# Altium schematic sheet 02_ RF & Power.SchDoc
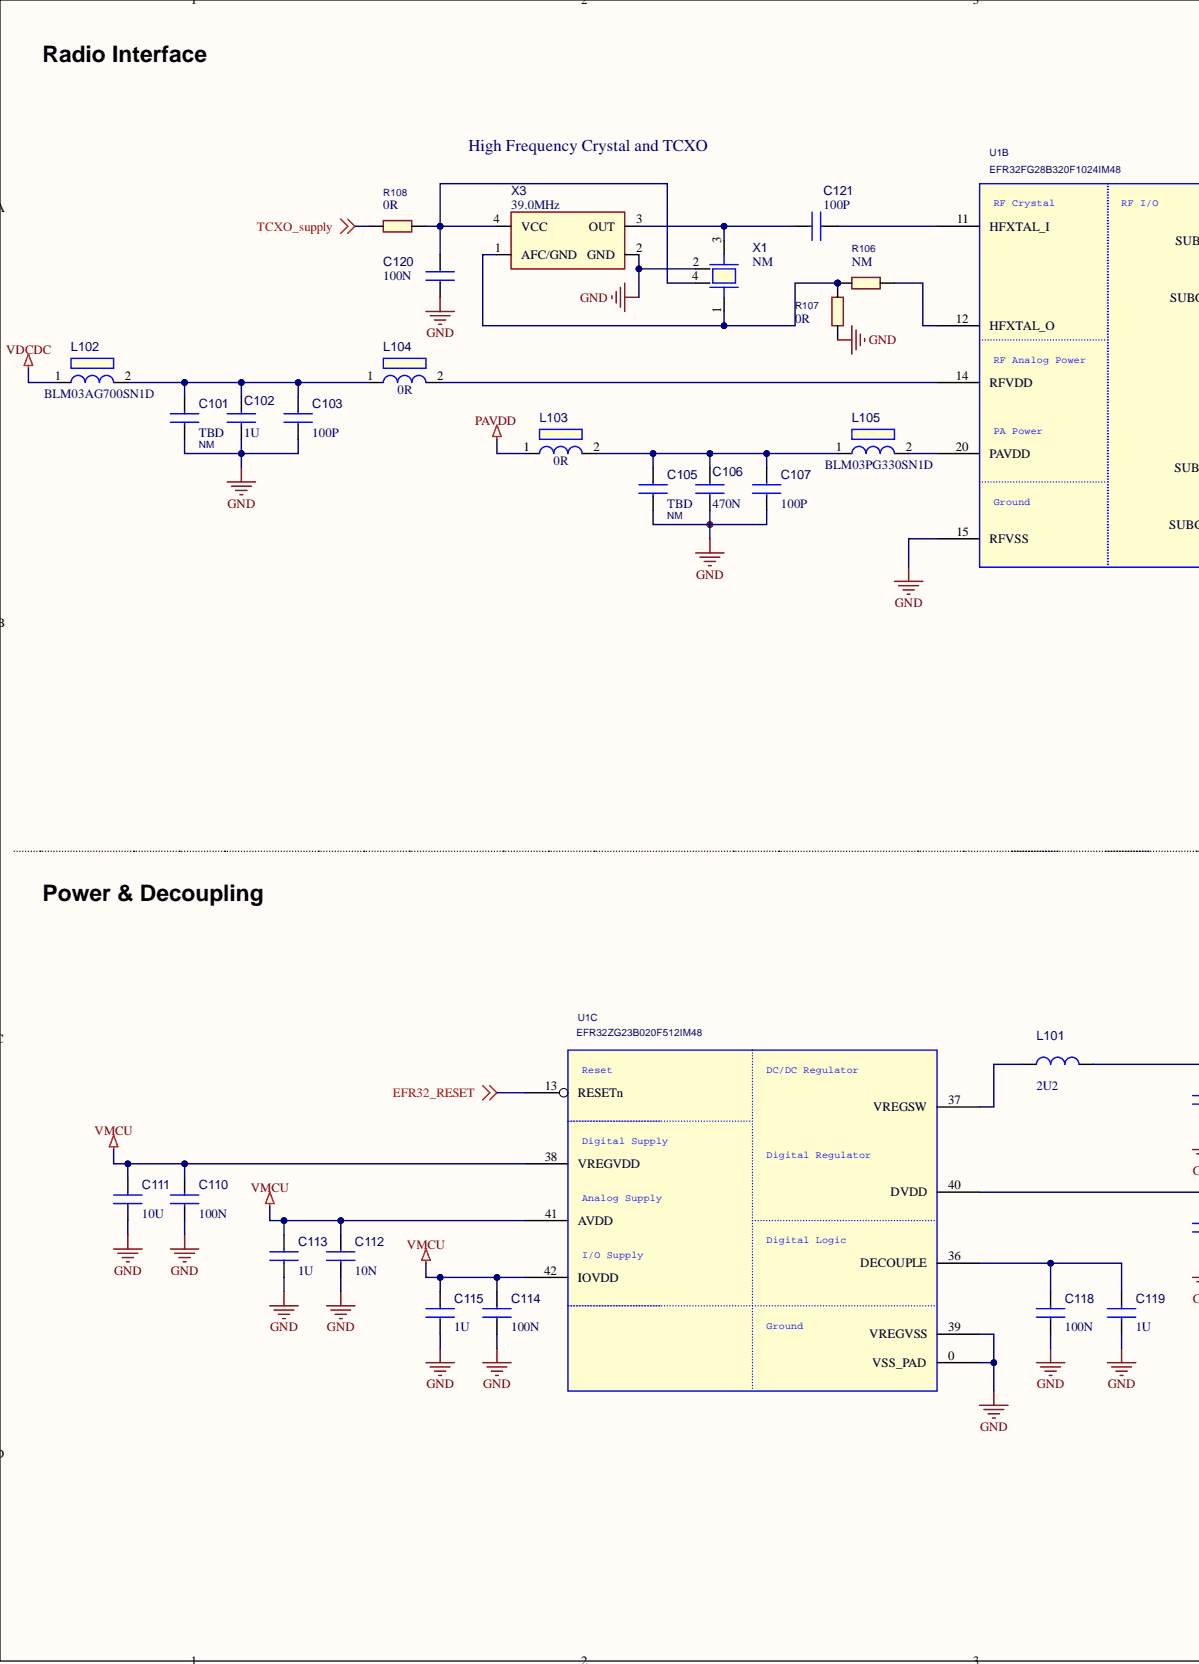
[diagram p0: 02_ RF & Power.SchDoc, left]
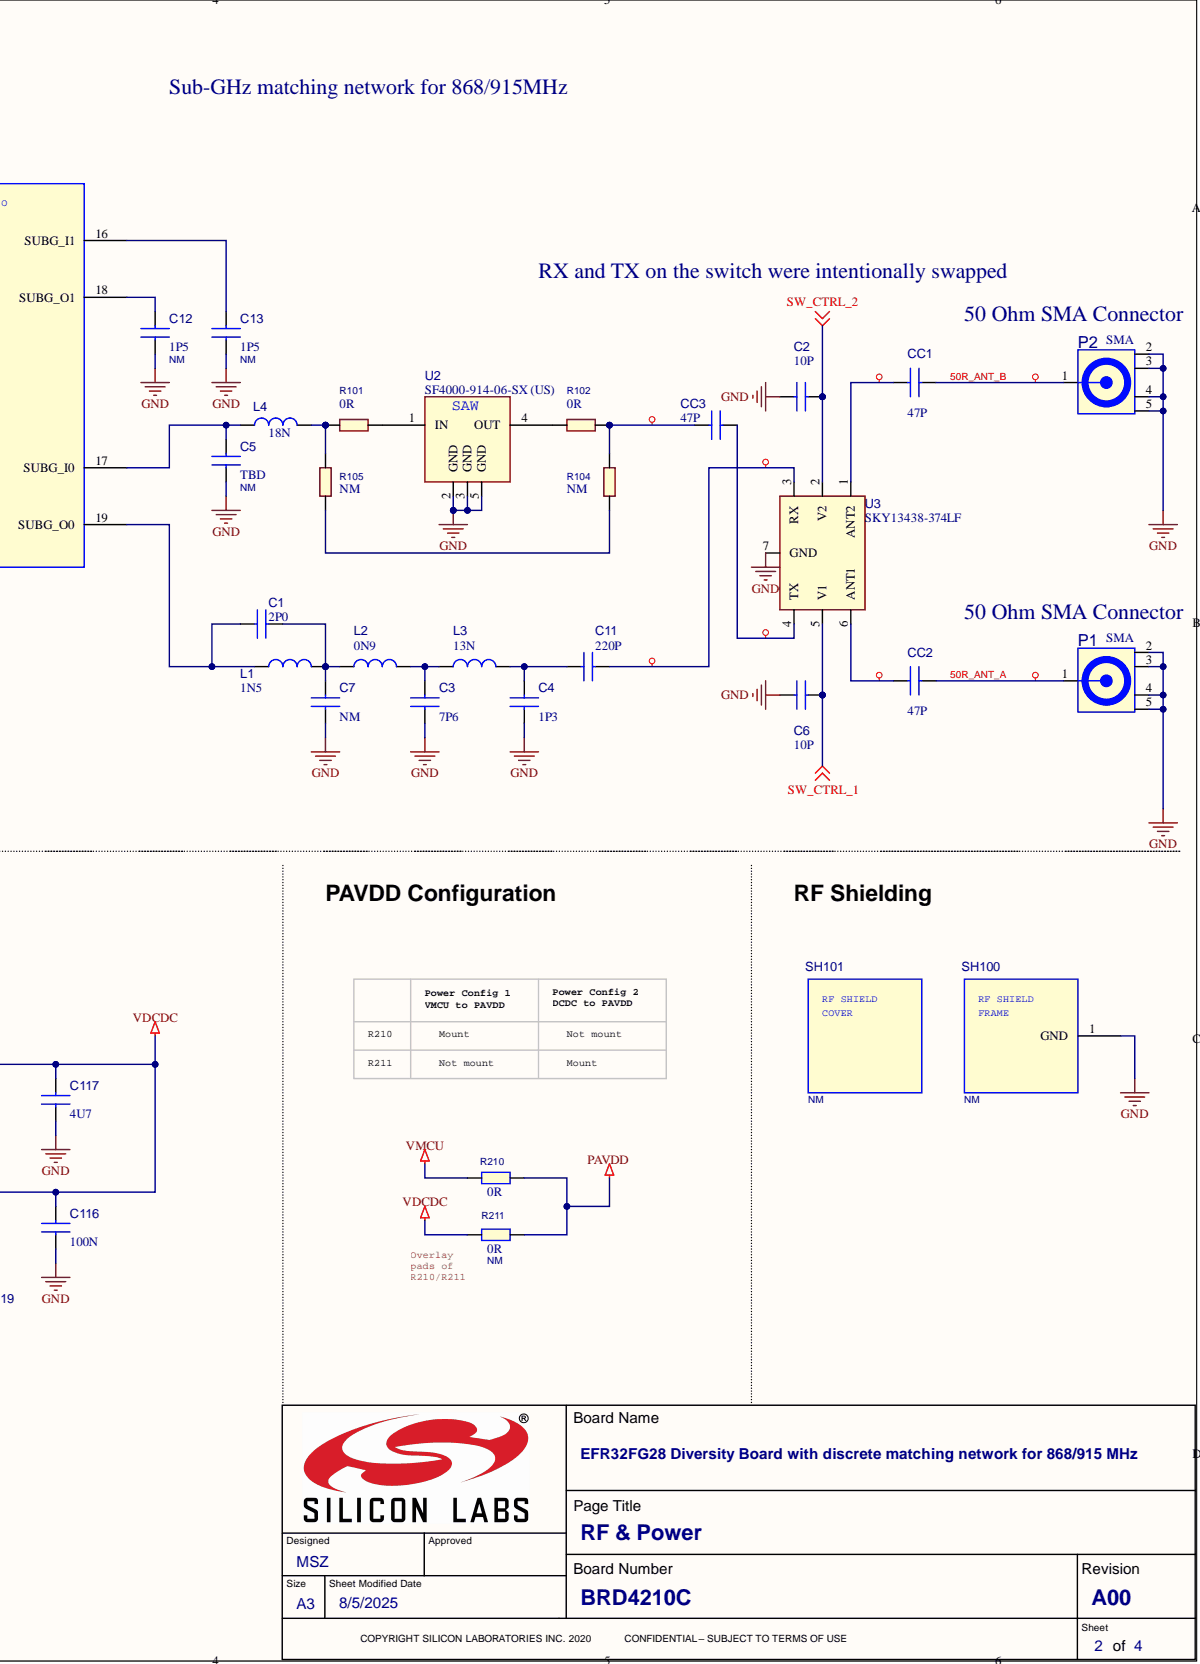
[diagram p1: 02_ RF & Power.SchDoc, right]

## Parts
C1  2P0  Part Reference: C1  [C0201_L]
C2  10P  [C0201_L]
C3  7P6  Part Reference: C3  [C0201_L]
C4  1P3  Part Reference: C4  [C0201_L]
C5  TBD  Not Mounted: NM  Part Reference: C5  [C0201_L]
C6  10P  [C0201_L]
C7  NM  [C0201_L]
C11  220P  Part Reference: C11  [C0201_L]
C12  1P5  Not Mounted: NM  Part Reference: C12  [C0201_L]
C13  1P5  Not Mounted: NM  Part Reference: C13  [C0201_L]
C101  TBD  Not Mounted: NM  Part Reference: C101  [C0201_L]
C102  1U  Part Reference: C102  [C0402_L]
C103  100P  Part Reference: C103  [C0201_L]
C105  TBD  Not Mounted: NM  Part Reference: C105  [C0201_L]
C106  470N  Part Reference: C106  [C0402_L]
C107  100P  Part Reference: C107  [C0201_L]
C110  100N  Part Reference: C110  [C0201_L]
C111  10U  Part Reference: C111  [C0603_L]
C112  10N  Part Reference: C112  [C0201_L]
C113  1U  Part Reference: C113  [C0402_L]
C114  100N  Part Reference: C114  [C0201_L]
C115  1U  Part Reference: C115  [C0402_L]
C116  100N  Part Reference: C116  [C0201_L]
C117  4U7  Part Reference: C117  [C0603_L]
C118  100N  Part Reference: C118  [C0201_L]
C119  1U  Part Reference: C119  [C0402_L]
C120  100N  [C0201_L]
C121  100P  [C0201_L]
CC1  47P  [C0201_L]
CC2  47P  [C0201_L]
CC3  47P  [C0201_L]
L1  1N5  Part Reference: L1  [COIL_0201_L]
L2  0N9  Part Reference: L2  [COIL_0201_L]
L3  13N  Part Reference: L3  [COIL_0201_L]
L4  18N  Part Reference: L4  [COIL_0201_L]
L101  2U2  Part Reference: L101  [COIL_1008_L]
L102  BLM03AG700SN1D  Part Reference: L102  [COIL_MUR_BLM03_0201]
L103  0R  Part Reference: L103  [COIL_MUR_BLM03_0201]
L104  0R  Part Reference: L104  [COIL_MUR_BLM03_0201]
L105  BLM03PG330SN1D  Part Reference: L105  [COIL_MUR_BLM03_0201]
P1  SMA  Part Reference: P1  [TE_SMA_1814832_TH_VER]
P2  SMA  [TE_SMA_1814832_TH_VER]
R101  0R  [R0201_L]
R102  0R  [R0201_L]
R104  NM  [R0201_L]
R105  NM  [R0201_L]
R106  NM  [R0201_L]
R107  0R  [R0201_L]
R108  0R  [R0201_L]
R210  0R  Part Reference: R210  [R0402_L]
R211  0R  Not Mounted: NM  Part Reference: R211  [R0402_L]
SH100  Not Mounted: NM  Part Reference: SH100  [Shield_21x21mm]  (hidden comment: RF_SHIELD_FRAME_36103205S)
SH101  Not Mounted: NM  Part Reference: SH101  (hidden comment: RF_SHIELD_COVER_36003200S)
U1  EFR32FG28B320F1024IM48  [QFN48_M040_6.0X6.0_EP_SL]  (parts B,C)
U2  SF4000-914-06-SX (US)  [SF1256]
U3  SKY13438-374LF  [SKY13438-374LF]
X1  NM  Part Reference: X1  [X_SSS_DSX211SH_2.05X1.65-4]
X3  39.0MHz  [TCXO_7Z-38.400MBG-T_SMD2016_TXC]

## Nets
EFR32_RESET: U1.13(RESETn)
GND: C2.1 C3.2 C4.2 C5.2 C6.1 C7.2 C12.2 C13.2 C101.2 C102.2 C103.2 C105.2 C106.2 C107.2 C110.2 C111.2 C112.2 C113.2 C114.2 C115.2 C116.2 C117.2 C118.2 C119.2 C120.2 P1.2 P1.3 P1.4 P1.5 P2.2 P2.3 P2.4 P2.5 R107.2 SH100.1 U1.0(VSS_PAD) U1.15(RFVSS) U1.39(VREGVSS) U2.2(GND) U2.3(GND) U2.5(GND) U3.7(GND) X1.2 X3.2(GND)
PAVDD: L103.1 R210.1 R211.2
SW_CTRL_1: C6.2 U3.5(V1)
SW_CTRL_2: C2.2 U3.2(V2)
TCXO_supply: R108.1
VDCDC: C116.1 C117.1 L101.2 L102.1 R211.1 U1.40(DVDD)
VMCU: C110.1 C111.1 C112.1 C113.1 C114.1 C115.1 R210.2 U1.38(VREGVDD) U1.41(AVDD) U1.42(IOVDD)
50R_ANT_A: CC2.2 P1.1
50R_ANT_B: CC1.2 P2.1
NetC1_1: C1.1 L1.1 U1.19(SUBG_O0)
NetC1_2: C1.2 C7.1 L1.2 L2.1
NetC3_1: C3.1 L2.2 L3.1
NetC4_1: C4.1 C11.1 L3.2
NetC5_1: C5.1 L4.1 U1.17(SUBG_I0)
NetC11_2: C11.2 U3.3(RX)
NetC12_1: C12.1 U1.18(SUBG_O1)
NetC13_1: C13.1 U1.16(SUBG_I1)
NetC101_1: C101.1 C102.1 C103.1 L102.2 L104.1
NetC105_1: C105.1 C106.1 C107.1 L103.2 L105.1
NetC118_1: C118.1 C119.1 U1.36(DECOUPLE)
NetC120_1: C120.1 R108.2 X1.4 X3.4(VCC)
NetC121_1: C121.1 X1.3 X3.3(OUT)
NetC121_2: C121.2 U1.11(HFXTAL_I)
NetCC1_1: CC1.1 U3.1(ANT2)
NetCC2_1: CC2.1 U3.6(ANT1)
NetCC3_1: CC3.1 R102.2 R104.1
NetCC3_2: CC3.2 U3.4(TX)
NetL4_2: L4.2 R101.1 R105.1
NetL101_1: L101.1 U1.37(VREGSW)
NetL104_2: L104.2 U1.14(RFVDD)
NetL105_2: L105.2 U1.20(PAVDD)
NetR101_2: R101.2 U2.1(IN)
NetR102_1: R102.1 U2.4(OUT)
NetR104_2: R104.2 R105.2
NetR106_1: R106.1 R107.1 X1.1 X3.1(AFC/GND)
NetR106_2: R106.2 U1.12(HFXTAL_O)

## Library data of the parts
C1 = C0201: Mfg: Murata; Mfg #: GRM0335C1H1R9BA01D; Tolerance: ±0.1pF; Value: 1P9; Component Kind: Standard; PCB Footprint: C0201_L; Technology: C0G
C2 C6 CC1-CC3 = C0201: Part Reference: CC11; Mfg: Murata; Mfg #: GJM0335C1E180GB01D; Tolerance: ±2%; Component Kind: Standard; PCB Footprint: C0201_L; Technology: C0G
  C2 C6: Value: 10P
  CC1-CC3: Value: 47P
C3 = C0201: Mfg: Murata; Mfg #: GRM0335C1H7R2BA01D; Tolerance: ±0.1pF; Value: 7P2; Component Kind: Standard; PCB Footprint: C0201_L; Technology: C0G
C4 = C0201: Mfg: Murata; Mfg #: GRM0335C1H1R3BA01D; Tolerance: ±0.1pF; Component Kind: Standard; PCB Footprint: C0201_L; Technology: C0G; Value: 1P3
C5 = C0201: Component Kind: Standard; PCB Footprint: C0201_L; Value: TBD
C7 = C0201: Part Reference: C1; Mfg: Murata; Mfg #: GRM0335C1H1R9BA01D; Tolerance: ±0.1pF; Component Kind: Standard; PCB Footprint: C0201_L; Technology: C0G; Value: NM
C11 = C0201: Mfg: Murata; Mfg #: GRM0335C1H221GA01D; Tolerance: ±2%; Component Kind: Standard; PCB Footprint: C0201_L; Technology: C0G; Value: 220P
C12 C13 = C0201: Mfg: Murata; Mfg #: GRM0335C1E1R5WA01D; Tolerance: ±0.05pF; Component Kind: Standard; PCB Footprint: C0201_L; Technology: C0G; Value: 1P5
C101 C105 = C0201: Rating: 50V; Tolerance: +/-0.05P; Component Kind: Standard; PCB Footprint: C0201_L; Technology: C0G; Value: TBD
C102 C113 C115 C119 = C0402: Rating: 6.3V; Tolerance: 10%; Component Kind: Standard; PCB Footprint: C0402_L; Technology: X7R; Value: 1U
C103 C107 = C0201: Rating: 25V; Tolerance: 5%; Component Kind: Standard; PCB Footprint: C0201_L; Technology: C0G; Value: 100P
C106 = C0402: Rating: 10V; Tolerance: 10%; Component Kind: Standard; PCB Footprint: C0402_L; Technology: X7R; Value: 470N
C110 C114 C116 C118 = C0201: Rating: 16V; Tolerance: 20%; Component Kind: Standard; PCB Footprint: C0201_L; Technology: X7S; Value: 100N
C111 = C0603: Rating: 25V; Tolerance: 10%; Component Kind: Standard; PCB Footprint: C0603_L; Technology: X5R; Value: 10U
C112 = C0201: Rating: 16V; Tolerance: 10%; Component Kind: Standard; PCB Footprint: C0201_L; Technology: X7S; Value: 10N
C117 = C0603: Rating: 6.3V; Tolerance: 10%; Component Kind: Standard; PCB Footprint: C0603_L; Technology: X7R; Value: 4U7
C120 C121 = C0201: Part Reference: C13; Mfg: Murata; Mfg #: GRM0335C1E1R5WA01D; Tolerance: ±0.05pF; Component Kind: Standard; PCB Footprint: C0201_L; Technology: C0G
  C120: Value: 100N
  C121: Value: 100P
L1 = L0201: Mfg: Murata; Mfg #: LQP03HQ1N5W02D; Tolerance: ±0.05nH; Component Kind: Standard; PCB Footprint: COIL_0201_L; Value: 1N5
L2 = L0201: Mfg: Murata; Mfg #: LQP03HQ1N3W02D; Tolerance: ±0.05nH; Value: 1N3; Component Kind: Standard; PCB Footprint: COIL_0201_L
L3 = L0201: Mfg: Murata; Mfg #: LQP03HQ13NH02D; Tolerance: ±3%; Component Kind: Standard; PCB Footprint: COIL_0201_L; Value: 13N
L4 = L0201: Mfg: Murata; Mfg #: LQP03HQ18NH02D; Tolerance: ±3%; Component Kind: Standard; PCB Footprint: COIL_0201_L; Value: 18N
L101 = L1008: Mfg: Murata; Mfg #: DFE2HCAH2R2MJ0L; Tolerance: +/-20%; Component Kind: Standard; PCB Footprint: COIL_1008_L; Value: 2U2
L102 L105 = BLM03AX: Mfg: Murata; Component Kind: Standard; PCB Footprint: COIL_MUR_BLM03_0201; Price_1K: 0.05
  L102: Mfg #: BLM03AG700SN1D; Value: BLM03AG700SN1D
  L105: Mfg #: BLM03PG330SN1D; Value: BLM03PG330SN1D
L103 L104 = BLM03AX: Component Kind: Standard; PCB Footprint: COIL_MUR_BLM03_0201; Price_1K: 0.05; Value: 0R
P1 = SMA_TH_WELLSHOW: Mfg #: C02AN31P00101; Component Kind: Standard; Mfg: Wellshow; PCB Footprint: TE_SMA_1814832_TH_VER; Price_1K: 1.46; Value: SMA
P2 = SMA_TH_WELLSHOW: Part Reference: P1; Mfg #: C02AN31P00101; Component Kind: Standard; Mfg: Wellshow; PCB Footprint: TE_SMA_1814832_TH_VER; Price_1K: 1.46; Value: SMA
R101 R102 R106-R108 = R0201: Component Kind: Standard; Library Name: BRD4210A_Library.SchLib; Price_1K: 0.005
  R101 R102 R107 R108: Value: 0R
  R106: Value: NM
R104 R105 = R0201: Not Mounted: NM; Component Kind: Standard; Library Name: BRD4210A_Library.SchLib; Price_1K: 0.005; Value: NM
R210 R211 = R0402: Component Kind: Standard; PCB Footprint: R0402_L; Price_1K: 0.005; Value: 0R
SH100 = RF_SHIELD_FRAME_36103205S: Mfg: Würth Elektronik; Component Kind: Standard; Mfg #: 36103205S; PCB Footprint: Shield_21x21mm; Price_1k: 1.00; Value: RF_SHIELD_FRAME_36103205S
SH101 = RF_SHIELD_COVER_36003200S: Mfg: Würth Elektronik; Component Kind: Standard; Mfg #: 36003200S; Price_1k: 0.944; Value: RF_SHIELD_COVER_36003200S
U1 = EFR32xG23_QFN48: Part Reference: U1; MFG: Silicon Labs; MFG #: EFR32ZG23B020F512IM48-CR; Component Kind: Standard; PCB Footprint: QFN48_M040_6.0X6.0_EP_SL; Value: EFR32FG28B320F1024IM48
U2 = SF4000-914-06-SX: B2645 from Qualcomm also fits; Mfg: ACTE; Component Kind: Standard; Library Name: BRD4210A_Library.SchLib; Mfg #: SF4000-914-06-SX; Value: SF4000-914-06-SX (US)
U3 = SKY13438-374LF: Mfg: Infineon; Mfg #: BGS12WN6E6327XTSA1; Component Kind: Standard; Library Name: BRD4210A_Library.SchLib; Value: SKY13438-374LF
X1 = DSX211SH: Mfg: Tai-Saw; Mfg #: TZ3541C; PCB Footprint: KDS_DSX211SH_2016; Value: 39.000 MHz; Component Kind: Standard; Price_1K: 0.512
X3 = 7Z-38.400MBG-T: Part Reference: X1; Mfg: TXC; Component Kind: Standard; Library Name: BRD4210A_Library.SchLib; Mfg #: 7Z-38.400MBG-T
